# Altium schematic sheet PMOD_CAN_BUS_Controller.SchDoc(PMOD_CAN_BUS_Controller)
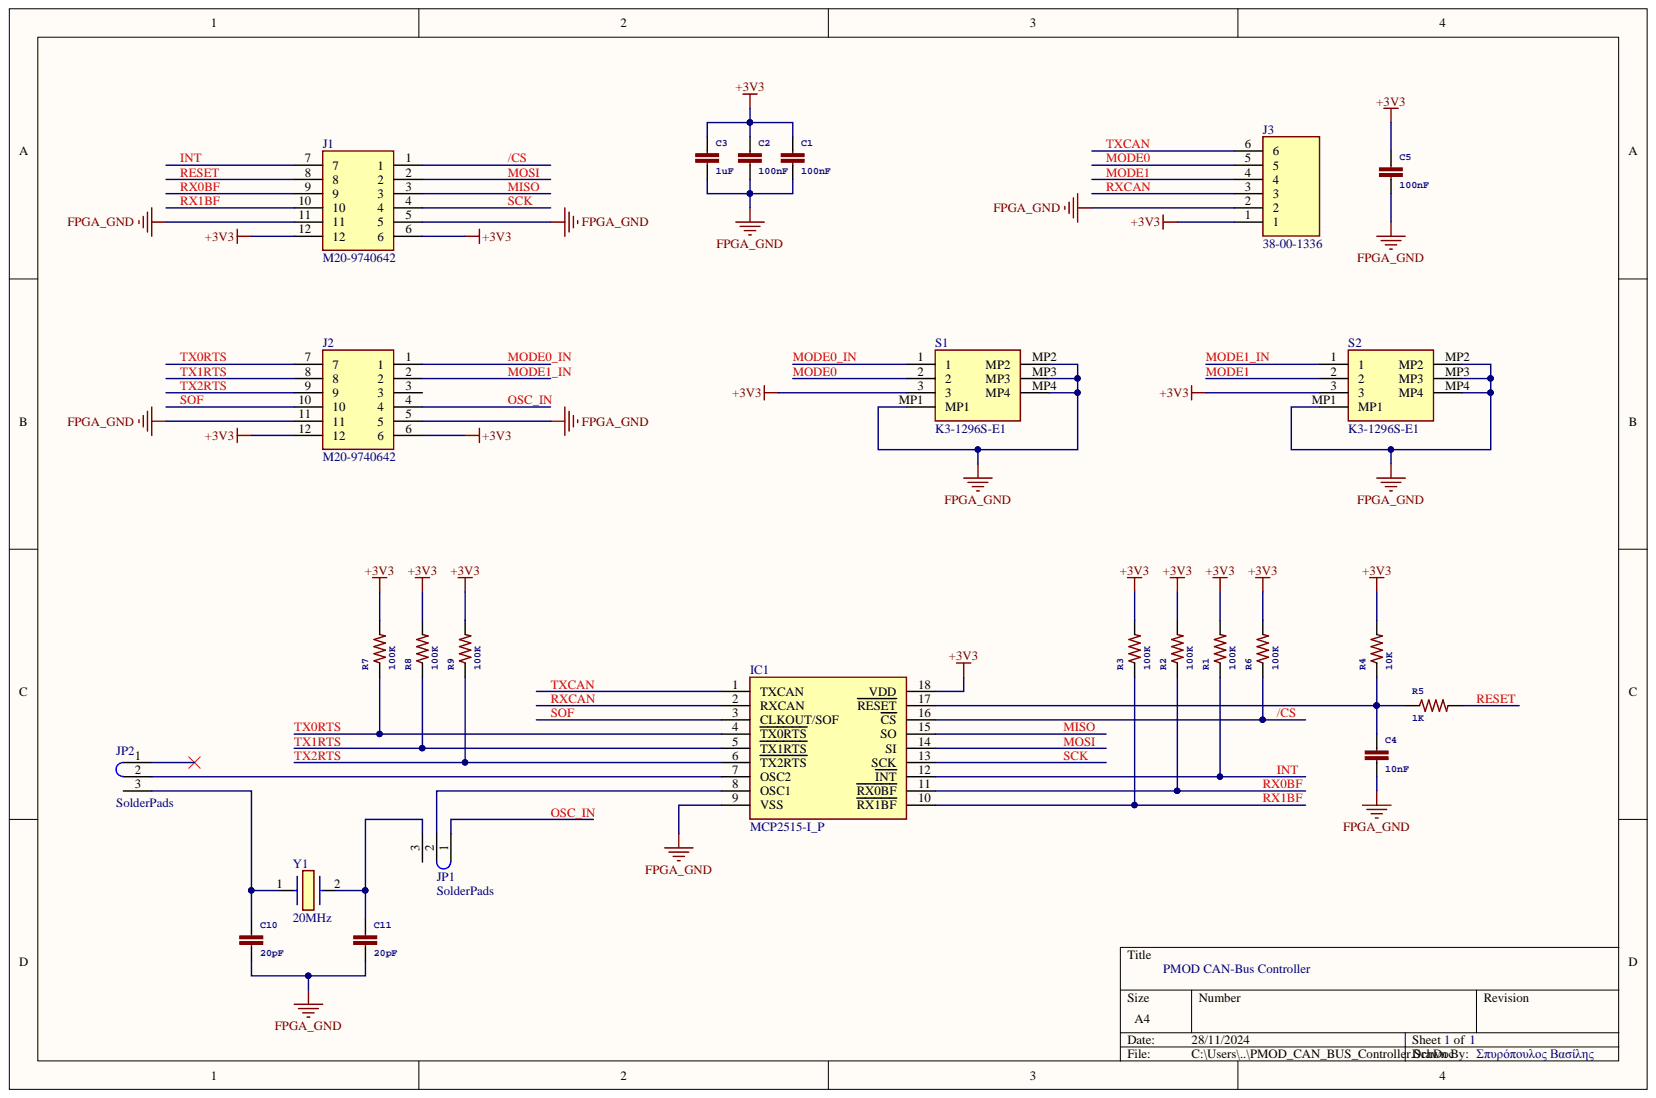
[diagram p0: PMOD_CAN_BUS_Controller.SchDoc]

## Parts
C1  100nF  [C0603]
C2  100nF  [C0603]
C3  1uF  [C0805]
C4  10nF  [C0603]
C5  100nF  [C0603]
C10  20pF  [C0805]
C11  20pF  [C0805]
IC1  MCP2515-I_P  [DIP794W56P254L2286H533Q18N]
J1  M20-9740642  [M209740642]
J2  M20-9740642  [M209740642]
J3  38-00-1336  [38001336]
JP1  SolderPads  [Solder_Jumber_3pads_open]
JP2  SolderPads  [Solder_Jumber_3pads_open]
R1  100K  [R0603]
R2  100K  [R0603]
R3  100K  [R0603]
R4  10K  [R0603]
R5  1K  [R0603]
R6  100K  [R0603]
R7  100K  [R0603]
R8  100K  [R0603]
R9  100K  [R0603]
S1  K3-1296S-E1  [K31296SE1]
S2  K3-1296S-E1  [K31296SE1]
Y1  20MHz  [FOXSLF16020]

## Nets
+3V3: C1.1 C2.1 C3.1 C5.1 IC1.18(VDD) J1.6 J1.12 J2.6 J2.12 J3.1 R1.2 R2.2 R3.2 R4.2 R6.2 R7.2 R8.2 R9.2 S1.3 S2.3
FPGA_GND: C1.2 C2.2 C3.2 C4.2 C5.2 C10.2 C11.2 IC1.9(VSS) J1.5 J1.11 J2.5 J2.11 J3.2 S1.MP1 S1.MP2 S1.MP3 S1.MP4 S2.MP1 S2.MP2 S2.MP3 S2.MP4
/CS: IC1.16(CS) J1.1 R6.1
INT: IC1.12(INT) J1.7 R1.1
MISO: IC1.15(SO) J1.3
MODE0: J3.5 S1.2
MODE0_IN: J2.1 S1.1
MODE1: J3.4 S2.2
MODE1_IN: J2.2 S2.1
MOSI: IC1.14(SI) J1.2
OSC_IN: J2.4 JP1.1
RESET: J1.8 R5.2
RX0BF: IC1.11(RX0BF) J1.9 R2.1
RX1BF: IC1.10(RX1BF) J1.10 R3.1
RXCAN: IC1.2(RXCAN) J3.3
SCK: IC1.13(SCK) J1.4
SOF: IC1.3(CLKOUT/SOF) J2.10
TX0RTS: IC1.4(TX0RTS) J2.7 R7.1
TX1RTS: IC1.5(TX1RTS) J2.8 R8.1
TX2RTS: IC1.6(TX2RTS) J2.9 R9.1
TXCAN: IC1.1(TXCAN) J3.6
NetC4_1: C4.1 IC1.17(RESET) R4.1 R5.1
NetC10_1: C10.1 JP2.3 Y1.1
NetC11_1: C11.1 JP1.3 Y1.2
NetIC1_7: IC1.7(OSC2) JP2.2
NetIC1_8: IC1.8(OSC1) JP1.2

Not connected: J2 3; JP2 1

## Library data of the parts
C1 C2 C4 C5 = C-EUC0603: Library Name: SamacSys.SchLib; Component Kind: Standard
C3 C10 C11 = C-EUC0805: Library Name: SamacSys.SchLib; Component Kind: Standard
IC1 = MCP2515-I_P: Integrated Circuit; Manufacturer_Part_Number: MCP2515-I/P; Manufacturer_Name: Microchip; Mouser Price/Stock: https://www.mouser.co.uk/ProductDetail/Microchip-Technology/MCP2515-I-P?qs=hklUZQQMghMXVOd4j8inGA%3D%3D; Mouser Part Number: 579-MCP2515-I/P; Height: 5.334mm; Datasheet Link: http://componentsearchengine.com/Datasheets/3/MCP2515-I_P.pdf; Component Kind: Standard; Arrow Price/Stock: https://www.arrow.com/en/products/mcp2515-ip/microchip-technology?region=nac; Arrow Part Number: MCP2515-I/P
J1 J2 = M20-9740642: Connector; Manufacturer_Name: HARWIN; Mouser Price/Stock: https://www.mouser.com/Search/Refine.aspx?Keyword=855-M20-9740642; Mouser Part Number: 855-M20-9740642; Manufacturer_Part_Number: M20-9740642; Library Name: SamacSys.SchLib; Height: 5.28mm; Datasheet Link: https://componentsearchengine.com/Datasheets/1/M20-9740642.pdf; Component Kind: Standard
J3 = 38-00-1336: Connector; Manufacturer_Name: Molex; Mouser Price/Stock: https://www.mouser.co.uk/ProductDetail/Molex/38-00-1336?qs=vE%2F395LYEsdmmuGLxp%252Brpw%3D%3D; Mouser Part Number: 538-38-00-1336; Manufacturer_Part_Number: 38-00-1336; Height: 8.71mm; Datasheet Link: https://www.molex.com/pdm_docs/sd/038001336_sd.pdf; Component Kind: Standard
JP1 JP2 = Solder_Jumber_3pads_open: Library Name: SamacSys.SchLib; Component Kind: Standard
R1-R9 = R-US_R0603: Library Name: SamacSys.SchLib; Component Kind: Standard
S1 S2 = K3-1296S-E1: Switch; Manufacturer_Name: Korean Hroparts Elec; Manufacturer_Part_Number: K3-1296S-E1; Library Name: SamacSys.SchLib; Height: 1.6mm; Datasheet Link: https://datasheet.lcsc.com/szlcsc/Korean-Hroparts-Elec-K3-1296S-E1_C128955.pdf; Component Kind: Standard
Y1 = FOXSLF_160-20: Crystal or Oscillator; Manufacturer_Part_Number: FOXSLF/160-20; Manufacturer_Name: Fox; Mouser Price/Stock: https://www.mouser.co.uk/ProductDetail/Fox-Abracon/FOXSLF-160-20?qs=mzRxyRlhVduNDCvKOeVUEw%3D%3D; Mouser Part Number: 559-FOXS160-20-LF; Library Name: SamacSys.SchLib; Height: 3.6mm; Datasheet Link: https://datasheet.datasheetarchive.com/originals/distributors/SFDatasheet-1/sf-00021452.pdf; Component Kind: Standard; Arrow Price/Stock: https://www.arrow.com/en/products/foxslf160-20/fox-electronics; Arrow Part Number: FOXSLF/160-20
